# Altium schematic sheet 05 Power1.SchDoc
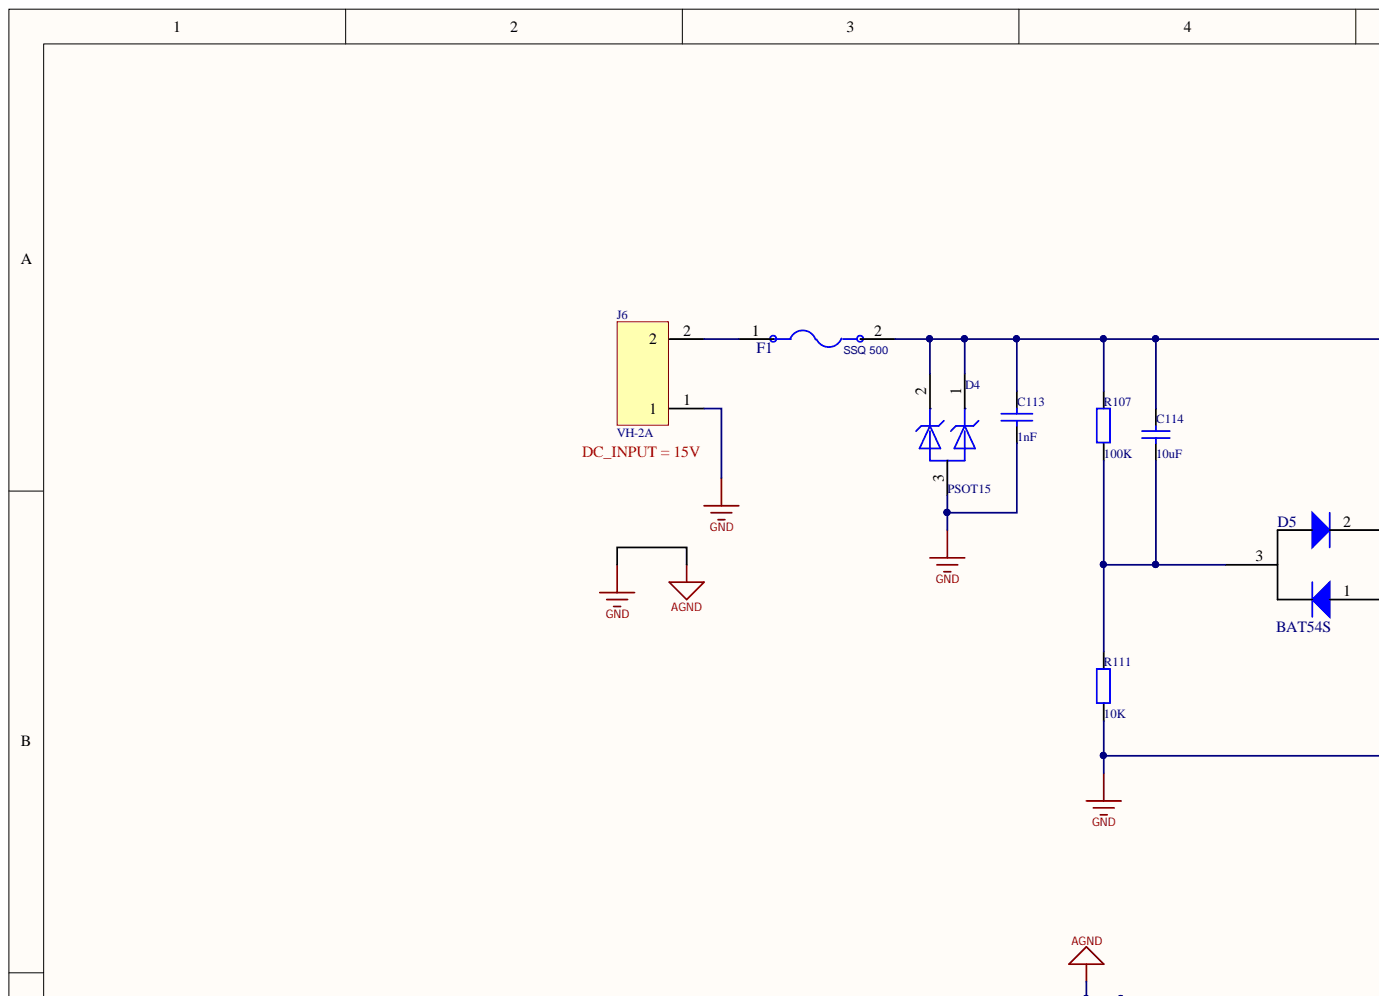
[diagram p0: 05 Power1.SchDoc, top left]
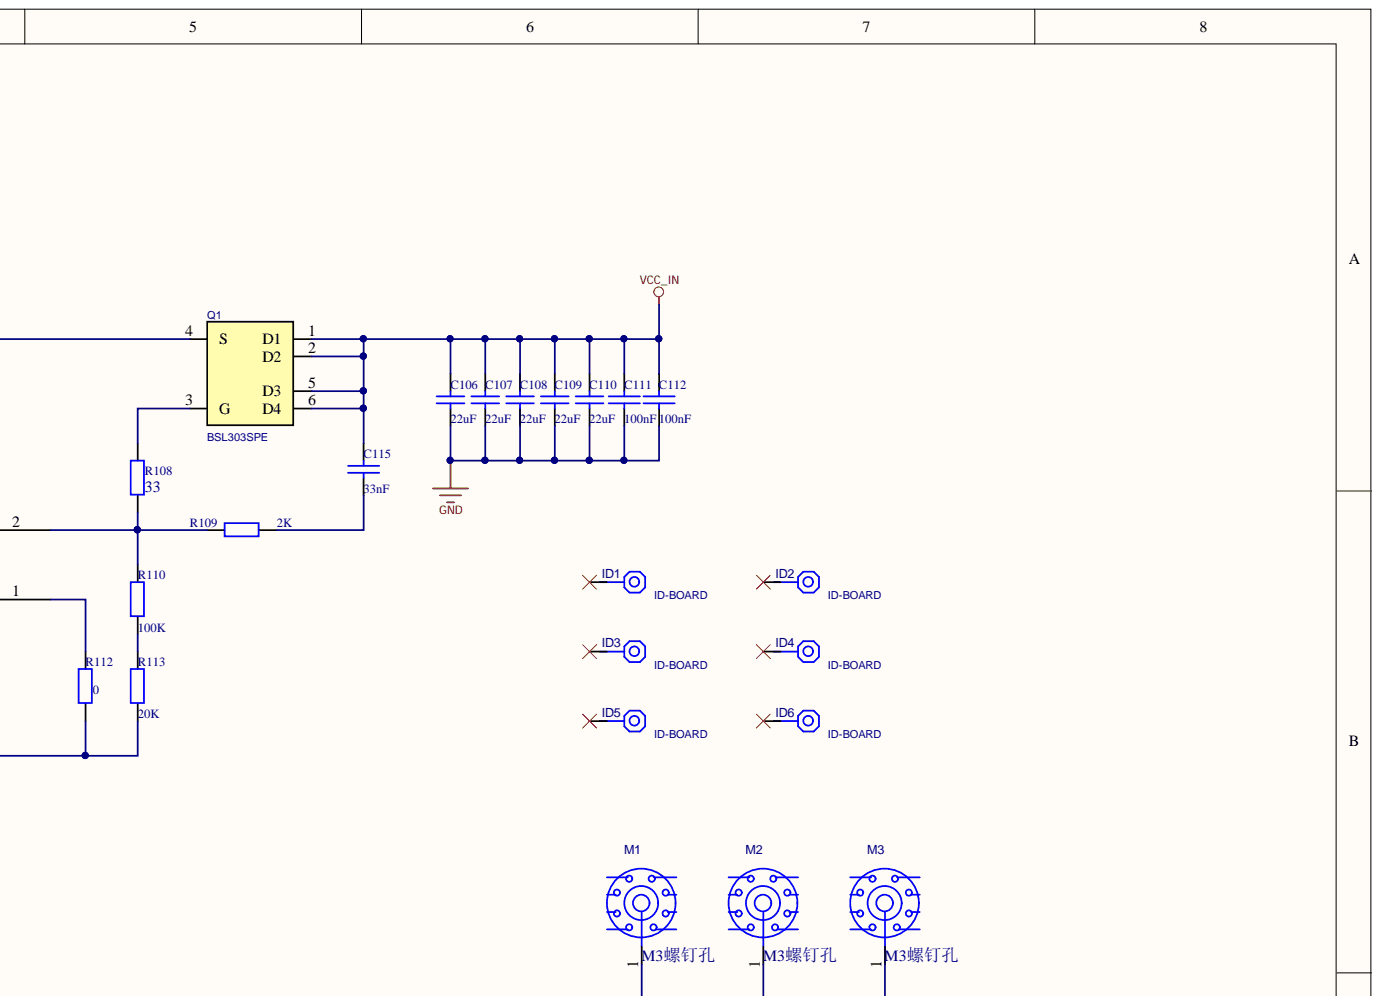
[diagram p1: 05 Power1.SchDoc, top right]
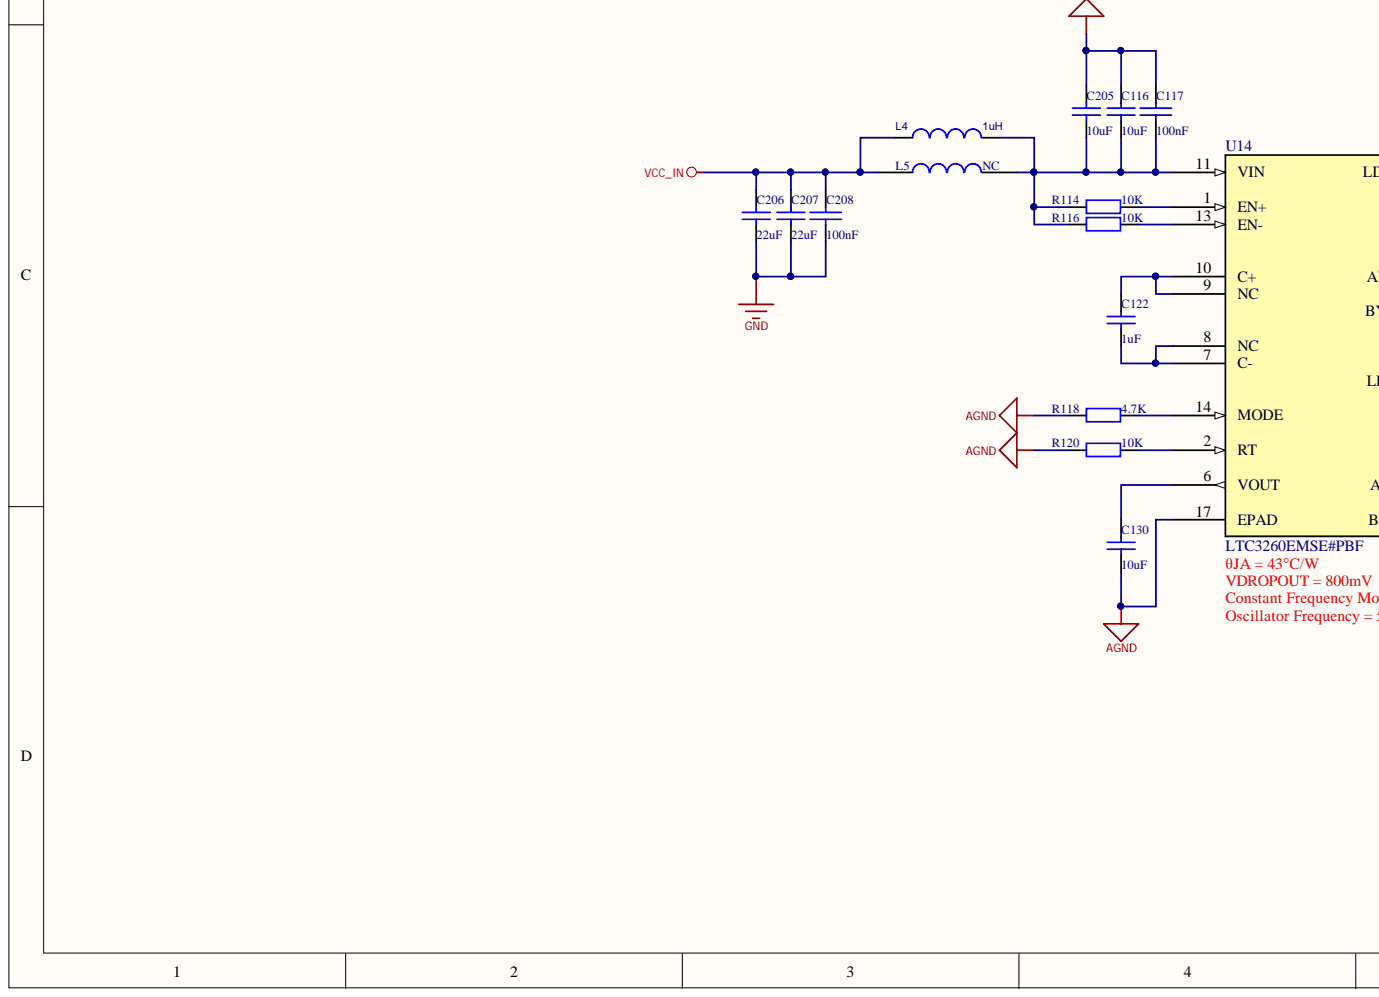
[diagram p2: 05 Power1.SchDoc, bottom left]
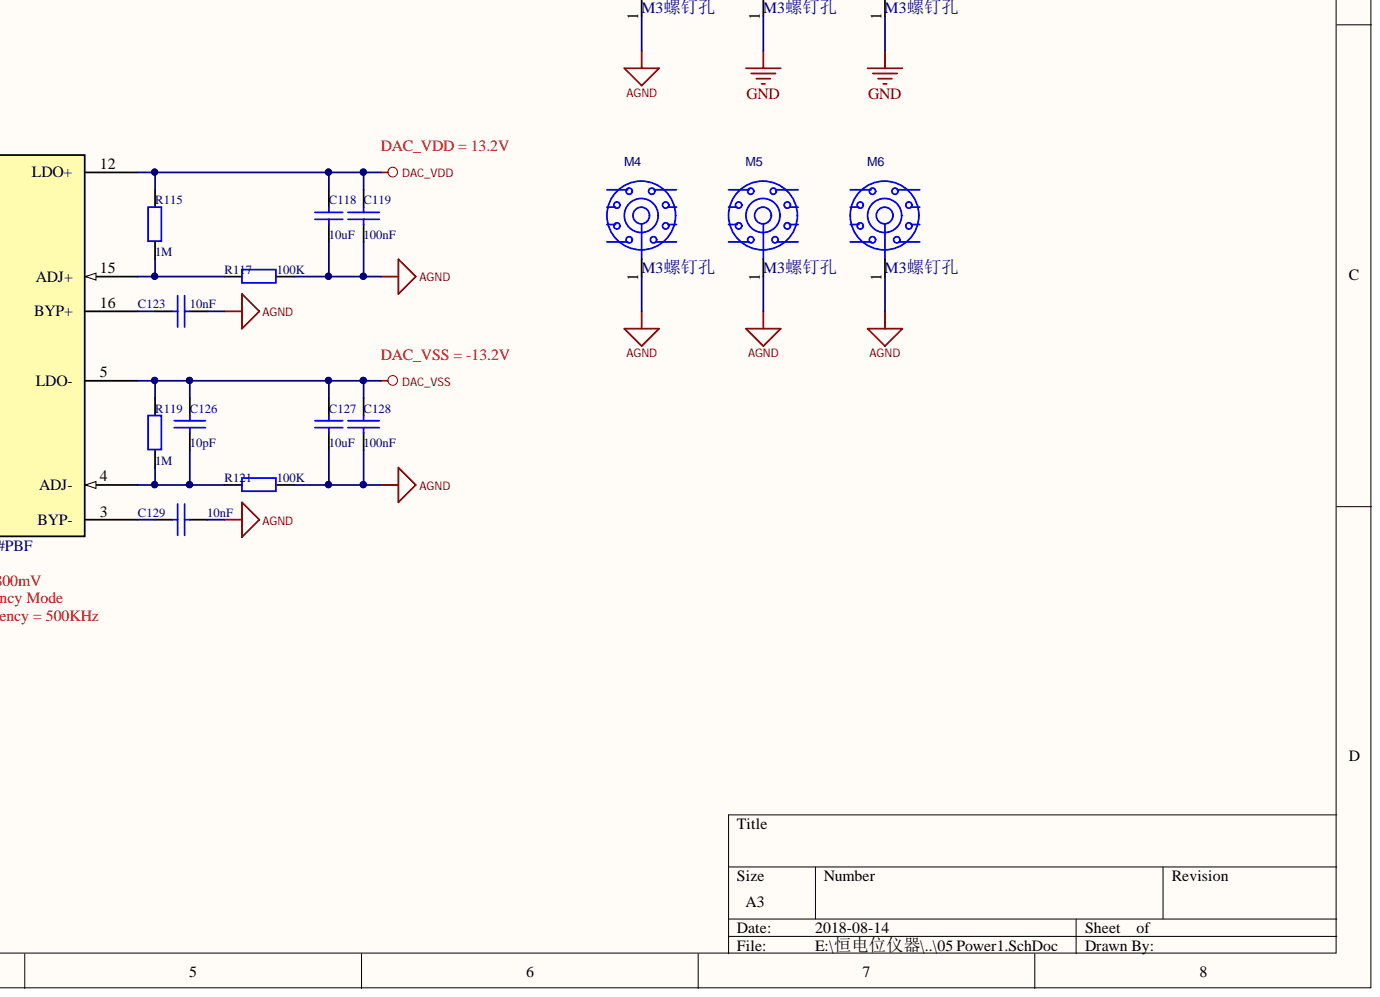
[diagram p3: 05 Power1.SchDoc, bottom right]

## Parts
C106  22uF  [SC0805]
C107  22uF  [SC0805]
C108  22uF  [SC0805]
C109  22uF  [SC0805]
C110  22uF  [SC0805]
C111  100nF  [SC0603]
C112  100nF  [SC0603]
C113  1nF  [SC0603]
C114  10uF  [SC0805]
C115  33nF  [SC0805]
C116  10uF  [SC0805]
C117  100nF  [SC0603]
C118  10uF  [SC0805]
C119  100nF  [SC0603]
C122  1uF  [SC0805]
C123  10nF  [SC0402]
C126  10pF  [SC0402]
C127  10uF  [SC0805]
C128  100nF  [SC0603]
C129  10nF  [SC0402]
C130  10uF  [SC0805]
C205  10uF  [SC0805]
C206  22uF  [SC0805]
C207  22uF  [SC0805]
C208  100nF  [SC0603]
D4  PSOT15  [SOT23]
D5  BAT54S  [SOT23]
F1  SSQ 500  [CRF1206-FX-R020ELF]
ID1  ID-BOARD  [ID-BOARD]
ID2  ID-BOARD  [ID-BOARD]
ID3  ID-BOARD  [ID-BOARD]
ID4  ID-BOARD  [ID-BOARD]
ID5  ID-BOARD  [ID-BOARD]
ID6  ID-BOARD  [ID-BOARD]
J6  value VH-2A  [VH-2A]  (hidden comment: Header 2)
L4  1uH  [HSL1008]
L5  0805,600Ω  [SL0805]  (value not drawn)
M1  HOLE3R2MM-5MM  [HOLE3R2MM-5MM]  (value not drawn)
M2  HOLE3R2MM-5MM  [HOLE3R2MM-5MM]  (value not drawn)
M3  HOLE3R2MM-5MM  [HOLE3R2MM-5MM]  (value not drawn)
M4  HOLE3R2MM-5MM  [HOLE3R2MM-5MM]  (value not drawn)
M5  HOLE3R2MM-5MM  [HOLE3R2MM-5MM]  (value not drawn)
M6  HOLE3R2MM-5MM  [HOLE3R2MM-5MM]  (value not drawn)
Q1  BSL303SPE  [TSOP-6]
R107  value 100K  [SR0402]  (hidden comment: 100K±1%)
R108  33  [SR0603]
R109  2K  [SR0603]
R110  100K  [SR0603]
R111  value 10K  [SR0402]  (hidden comment: 10K±1%)
R112  value 0  [SR0603]  (hidden comment: 0±5%)
R113  value 20K  [SR0402]  (hidden comment: 20K±1%)
R114  10K±1%  value 10K  [SR0402]
R115  value 1M  [SR0402]  (hidden comment: 1M±1%)
R116  10K±1%  value 10K  [SR0402]
R117  100K±1%  value 100K  [SR0402]
R118  value 4.7K  [SR0402]  (hidden comment: 4.7K±1%)
R119  value 1M  [SR0402]  (hidden comment: 1M±1%)
R120  value 10K  [SR0402]  (hidden comment: 10K±1%)
R121  100K±1%  value 100K  [SR0402]
U14  LTC3260EMSE#PBF  [MSOP-16_MSE]

## Nets
AGND: C116.1 C117.1 C118.2 C119.2 C123.2 C127.2 C128.2 C129.2 C130.2 C205.1 M1.1 M4.1 M5.1 M6.1 R117.2 R118.1 R120.2 R121.2 U14.17(EPAD)
DAC_VDD: C118.1 C119.1 R115.1 U14.12(LDO+)
DAC_VSS: C126.2 C127.1 C128.1 R119.1 U14.5(LDO-)
GND: C106.2 C107.2 C108.2 C109.2 C110.2 C111.2 C112.2 C113.1 C206.2 C207.2 C208.2 D4.3 J6.1 M2.1 M3.1 R111.2 R112.1 R113.1
VCC_IN: C106.1 C107.1 C108.1 C109.1 C110.1 C111.1 C112.1 C115.1 C206.1 C207.1 C208.1 L4.1 L5.1 Q1.1(D1) Q1.2(D2) Q1.5(D3) Q1.6(D4)
NetC113_2: C113.2 C114.1 D4.1 D4.2 F1.2 Q1.4(S) R107.2
NetC114_2: C114.2 D5.3 R107.1 R111.1
NetC115_2: C115.2 R109.2
NetC116_2: C116.2 C117.2 C205.2 L4.2 L5.2 R114.2 R116.2 U14.11(VIN)
NetC122_1: C122.1 U14.9(NC) U14.10(C+)
NetC122_2: C122.2 U14.7(C-) U14.8(NC)
NetC123_1: C123.1 U14.16(BYP+)
NetC126_1: C126.1 R119.2 R121.1 U14.4(ADJ-)
NetC129_1: C129.1 U14.3(BYP-)
NetC130_1: C130.1 U14.6(VOUT)
NetD5_1: D5.1 R112.2
NetD5_2: D5.2 R108.2 R109.1 R110.1
NetF1_1: F1.1 J6.2
NetQ1_3: Q1.3(G) R108.1
NetR110_2: R110.2 R113.2
NetR114_1: R114.1 U14.1(EN+)
NetR115_2: R115.2 R117.1 U14.15(ADJ+)
NetR116_1: R116.1 U14.13(EN-)
NetR118_2: R118.2 U14.14(MODE)
NetR120_1: R120.1 U14.2(RT)

Not connected: ID1 1; ID2 1; ID3 1; ID4 1; ID5 1; ID6 1

## Library data of the parts
C106-C110 C206 C207 = Cap Semi: Capacitor; Part_Comments: SMD陶瓷电容-25V-22uF-+/-20%-X5R-0805; DEVICE: CC0805MKX5R8BB226; ESR: 5mOhm; Vendor: Yageo; Voltage: 25V; Component Kind: Standard; Library Name: Miscellaneous Devices.IntLib; Signal Integrity: Cap Semi; Simulation: CAP; Value: 22uF
C111 C112 C117 C119 C128 C208 = CAP: Capacitor; Part_Comments: SMD陶瓷电容-50V-100nF-+/-10%-X7R-0603; COMPONENT_HIGH_MAX: 0.55MM; DEVICE: CC0603KRX7R9BB104; Vendor: Yageo; Voltage: 50V; Component Kind: Standard; Value: 100nF
C113 = CAP: Capacitor; Part_Comments: SMD陶瓷电容-50V-1nF-+/-5%-NPO-0402; Code: 101024500064; COMPONENT_HIGH_MAX: 0.55MM; DEVICE: CC0402JRNPO9BN102; Vendor: Yageo; Voltage: 50V; Component Kind: Standard; Value: 1nF
C114 C116 C118 C127 C130 C205 = Cap Semi: Capacitor; Part_Comments: SMD陶瓷电容-25V-10uF-+/-10%-X5R-0805; Code: 101024500042; DEVICE: CC0805KKX5R8BB106; Vendor: Yageo; Voltage: 25V; Component Kind: Standard; Library Name: Miscellaneous Devices.IntLib; Signal Integrity: Cap Semi; Simulation: CAP; Value: 10uF
C115 = CAP: Capacitor; Part_Comments: SMD陶瓷电容-50V-33nF-+/-10%-X7R-0805; Code: 101024533356; DEVICE: CC0805KRX7R9BB333; Vendor: Yageo; Voltage: 50V; Component Kind: Standard; Value: 33nF
C122 = Cap Semi: Capacitor; Part_Comments: SMD陶瓷电容-50V-1uF-+/-10%-X7R-0805; DEVICE: CC0805KKX7R9BB105; Vendor: Yageo; Voltage: 50V; Component Kind: Standard; Library Name: Miscellaneous Devices.IntLib; Signal Integrity: Cap Semi; Simulation: CAP; Value: 1uF
C123 C129 = CAP: Capacitor; Part_Comments: SMD陶瓷电容-50V-10nF-+/-10%-X7R-0402; COMPONENT_HIGH_MAX: 0.55MM; DEVICE: CC0402KRX7R9BB103; Vendor: Yageo; Voltage: 50V; Component Kind: Standard; Value: 10nF
C126 = CAP: Capacitor; Part_Comments: SMD陶瓷电容-50V-10pF-+/-5%-NPO-0402; Code: 101024500034; COMPONENT_HIGH_MAX: 0.55MM; DEVICE: CC0402JRNPO9BN100; Vendor: Yageo; Voltage: 50V; Component Kind: Standard; Value: 10pF
D4 = D2-3_D: 瞬态抑制二极管-15.0V-16.7V; Vendor: Protekdevices; Component Kind: Standard; Value: PSOT15; Library Name: Potentiostat.SchLib
D5 = BAT54S: Code: 101050050035; Component Kind: Standard; Library Name: CY7C63723.SchLib; Value: BAT54S
F1 = FUSE2: 保险管套件-快熔断-125V-0.5A-UL-0.235Ω; Value: Bel Fuse:SSQ 500; Vendor: Bel Fuse; Component Kind: Standard; Library Name: Potentiostat.SchLib
ID1-ID6 = ID-BOARD: PCB-MARK点ID-BOARD，亮铜直径40MIL，阻焊开窗直径80MIL，MARK点大小120MIL; DEVICE: PCB_MARKPOINT_A; Value: PCB_MARKPOINT_A; Component Kind: Standard; PKG_TYPE: ID-BOARD; Library Name: FAST_GC_FE.SCHLIB
J6 = Header 2_J: 2P-间距3.96.mm-7A连接器; Current: 7A; Vendor: 津达电子; Component Kind: Standard; Device: VH-2A; Part_Comments: 2P-间距3.96.mm-7A连接器; Library Name: FAST_GC_Lib.SchLib
L4 = IND: CODE: 101050050064; COMPONENT_HIGH_MAX: 1.2MM; Current: 2A; DEVICE: SWPA252012S1R0NT; Component Kind: Standard
L5 = INDUCTOR: 贴片磁珠频率-600Ω-±25%-0.25Ω-1.5A-0805; Code: 101037512601; Device: PZ2012U601; Value: PZ2012U601; Vendor: Sunlord; Component Kind: Standard; NC: NC; Part_Comments: 贴片磁珠频率-600Ω-±25%-0.25Ω-1.5A-0805
M1-M6 = HOLE-8STARS_2: M3螺钉孔; Component Kind: Standard; DEVICE: M3螺钉孔; PKG_TYPE: HOLE3R2MM-5MM
Q1 = Si3443DDV: MOSFET P-Channel 20-V (D-S); Code: 101050050073; Value: Infineon:BSL303SPE; Vendor: Infineon; Component Kind: Standard; Library Name: Potentiostat.SchLib
R107 R117 R121 = Res2: Resistor; Part_Comments: 片式厚膜电阻器-0.0625W-100KOHM-+/-1%-0402; DEVICE: RC0402FR-07100KL; Vendor: Yageo; Component Kind: Standard; Library Name: Miscellaneous Devices.IntLib
R108 = Res2: Resistor; Part_Comments: 片式厚膜电阻器-0.1W-33OHM-+/-1%-0603; Code: 101017303330; DEVICE: RC0603FR-0733RL; Vendor: Yageo; Component Kind: Standard; Value: 33
R109 = Res2: Resistor; Part_Comments: 片式厚膜电阻器-0.1W-2KOHM-+/-1%-0603; Code: 101017400012; DEVICE: RC0603FR-072KL; Vendor: Yageo; Component Kind: Standard; Value: 2K
R110 = Res2: Resistor; Part_Comments: 片式厚膜电阻器-0.1W-100KOHM-+/-1%-0603; Code: 101017310401; DEVICE: RC0603FR-07100KL; Vendor: Yageo; Component Kind: Standard; Value: 100K
R111 R114 R116 R120 = RES2: Resistor; Part_Comments: 片式厚膜电阻器-0.0625W-10KOHM-+/-1%-0402; Code: 101017400055; DEVICE: RC0402FR-0710KL; Vendor: Yageo; Component Kind: Standard
R112 = RES2: Resistor; Part_Comments: 片式厚膜电阻器-0.1W-0OHM-+/-5%-0603; Code: 101017300001; DEVICE: RC0603JR-070RL; Vendor: Yageo; Component Kind: Standard; NC: NC
R113 = RES2: Resistor; Part_Comments: 片式厚膜电阻器-0.0625W-20KOHM-+/-1%-0402; Code: 101017400079; DEVICE: RC0402FR-0720KL; Vendor: Yageo; Component Kind: Standard
R115 R119 = Res2: Resistor; Part_Comments: 片式厚膜电阻器-0.0625W-1MOHM-+/-1%-0402; Code: 101017400043; DEVICE: RC0402FR-071ML; Vendor: Yageo; Component Kind: Standard; Library Name: Miscellaneous Devices.IntLib
R118 = Res2: Resistor; Part_Comments: 片式厚膜电阻器-0.0625W-4.7KOHM-+/-1%-0402; Code: 101017400060; DEVICE: RC0402FR-074K7L; Vendor: Yageo; Component Kind: Standard; Library Name: Miscellaneous Devices.IntLib; Signal Integrity: Res; Simulation: RESISTOR
U14 = LTC3260EMSE: Low Noise Dual Supply Inverting Charge Pump; Vendor: Linear Technology; Component Kind: Standard; Value: LTC3260EMSE#PBF; Library Name: Potentiostat.SchLib
